# Altium schematic sheet SC20100S-Power.SchDoc(SC20100S-Power)
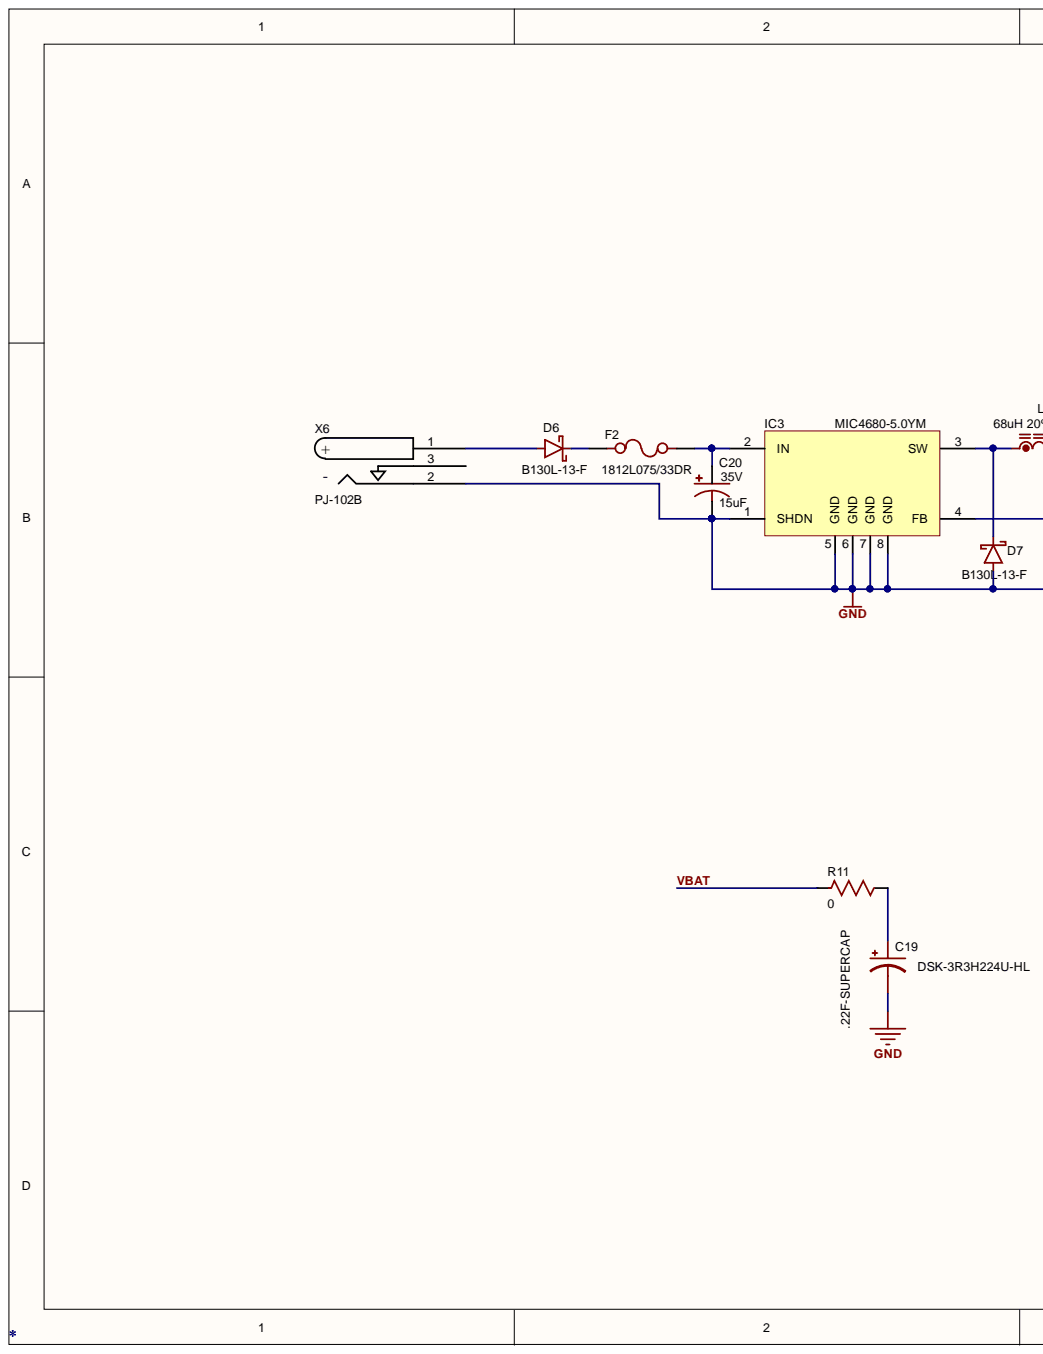
[diagram p0: SC20100S-Power.SchDoc, left]
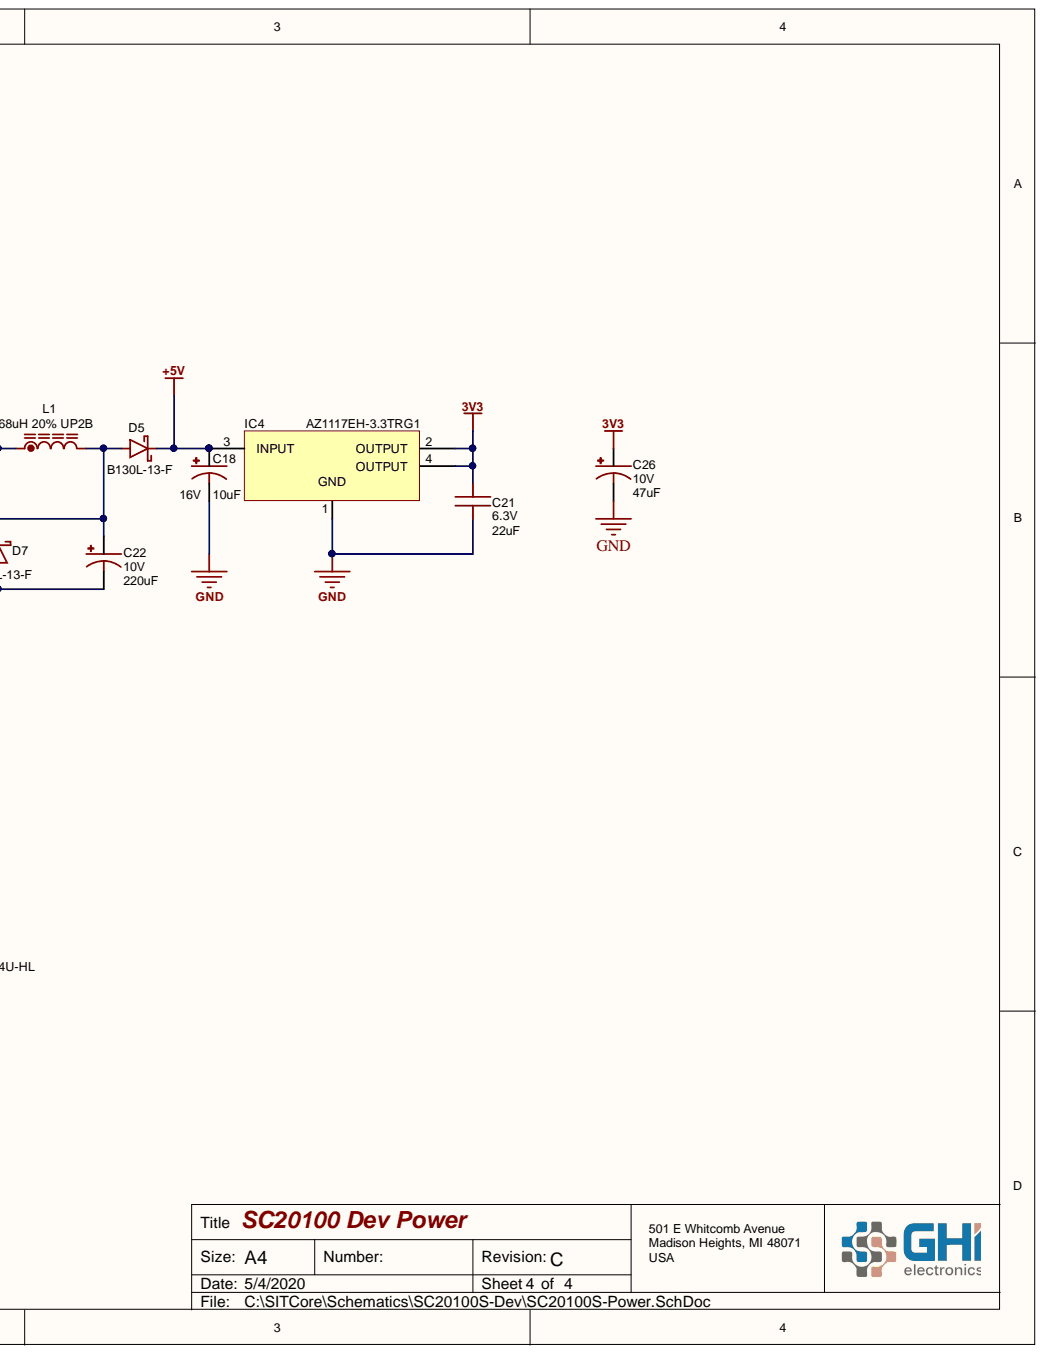
[diagram p1: SC20100S-Power.SchDoc, right]

## Parts
C18  value 10uF  Voltage: 16V  [CASE-B (3528 METRIC)]  (hidden comment: CASE-B  3528 Tant 10uF)
C19  .22F-SUPERCAP  value DSK-3R3H224U-HL  [DSK-RADIAL-6.8MM]
C20  value 15uF  Voltage: 35V  [CASE-D (7343 METRIC)]  (hidden comment: CASE-D 7343 Tant 15uF)
C21  value 22uF  Voltage: 6.3V  [C0805]  (hidden comment: 0805 Cap 22uF)
C22  value 220uF  Voltage: 10V  [CASE-D (7343 METRIC)]  (hidden comment: CASE-D 7343 Tant 220uF)
C26  value 47uF  Voltage: 10V  [CASE-B (3528 METRIC)]  (hidden comment: CASE-B  Tant 47uF)
D5  B130L-13-F  [SMA]
D6  B130L-13-F  [SMA]
D7  B130L-13-F  [SMA]
F2  value 1812L075/33DR  [1812 Fuse]
IC3  MIC4680-5.0YM  [8-Pin SOIC]
IC4  AZ1117EH-3.3TRG1  [SOT223]
L1  68uH 20% UP2B  Tolerance: 20%  Package: UP2B  Inductance: 68uH  [UP2BXXX]
R11  value 0  [R0603]  (hidden comment: 0603 Res 0)
X6  PJ-102B  [PJ-102B Power Connector]

## Nets
3V3: C21.2 C26.+ IC4.2(OUTPUT) IC4.4(OUTPUT)
+5V: C18.+ D5.K IC4.3(INPUT)
GND: C18.- C19.- C20.- C21.1 C22.- C26.- D7.A IC3.1(SHDN) IC3.5(GND) IC3.6(GND) IC3.7(GND) IC3.8(GND) IC4.1 X6.2
VBAT: R11.1
NetC19_+: C19.+ R11.2
NetC20_+: C20.+ F2.2 IC3.2(IN)
NetC22_+: C22.+ D5.A IC3.4(FB) L1.2
NetD6_A: D6.A X6.1
NetD6_K: D6.K F2.1
NetD7_K: D7.K IC3.3(SW) L1.1

Not connected: X6 3

## Library data of the parts
C18 = CASE-B Tant 10uF: 10uF ±10% 16V 800 mΩ @ 100kHz -55℃ ~ +125℃ CASE-B_3528 Tantalum Capacitors RoHS; Mfr.Part #: TPSB106K016R0800; Tolerance: ±10%; Package: CASE-B_3528; LCSC Part #: C273667; Digikey: 478-2398-1-ND; Component Kind: Standard; Category: Tantalum; Capacitance: 10uF; Library Name: GHI-Passives.SchLib
C19 = .22F-SUPERCAP: ELNA DSK-621 - 220mF Electric Double Layer Capacitor (EDLC/SuperCapacitor)    A 220 mF SuperCapacitor. Used for backup power on devices with low standby current; Manufacturer: Elna America; Mfr.Part #: DSK-3R3H224U-HL; Digikey: 604-1020-1-ND; Component Kind: Standard; Library Name: GHI.SchLib
C20 = CASE-D 7343 Tant 15uF: 15uF ±10% 35V 700 mΩ @ 100kHz -55℃ ~ +125℃ CASE-D_7343 Tantalum Capacitors RoHS; Mfr.Part #: 293D156X9035D2TE3; Tolerance: ±10%; Package: CASE-D_7343; LCSC Part #: C162325; Component Kind: Standard; Category: Tantalum; Capacitance: 15uF; Library Name: GHI-Passives.SchLib
C21 = 0805 Cap 22uF: 22uF ±20% 6.3V X5R 0805 Multilayer Ceramic Capacitors MLCC - SMD/SMT RoHS; Mfr.Part #: CL21A226MQCLRNC; Tolerance: ±20%; Package: 0805; LCSC Part #: C307530; Component Kind: Standard; Category: Ceramic; Capacitance: 22uF; Library Name: GHI-Passives.SchLib
C22 = CASE-D 7343 Tant 220uF: 220uF ±10% 10V 500 mΩ @ 100kHz -55℃ ~ +125℃ CASE-D_7343 Tantalum Capacitors RoHS; Mfr.Part #: T491D227K010AT; Manufacturer: KEMET; Tolerance: ±10%; Package: CASE-D_7343; LCSC Part #: C116577; Component Kind: Standard; Category: Tantalum; Capacitance: 220uF; Library Name: GHI-Passives.SchLib
C26 = CASE-B  Tant 47uF: 47uF ±10% 10V 1 Ω @ 100kHz -55℃ ~ +125℃ CASE-B_3528 Tantalum Capacitors RoHS; Mfr.Part #: TAJB476K010RNJ; Tolerance: ±10%; Package: CASE-B 3528; LCSC Part #: C22036; Component Kind: Standard; Category: Tantalum; Capacitance: 47uF; Library Name: GHI-Passives.SchLib
D5-D7 = B130L-13-F 1A Schottky SMA: 30V 1A 410mV @ 1A SMA(DO-214AC) Schottky Barrier Diodes (SBD) RoHS; Manufacturer: Diodes Incorporated; GHI Part Number: EPC-DB130L-13; Value: B130L-13-F; Package: SMA(DO-214AC); Mfr.Part #: B130L-13-F; Library Name: GHI.SchLib; Component Type: Diode; Component Kind: Standard
F2 = FUSE 33V 750MA 1812: Polymeric PTC Resettable Fuse 33V 750mA Ih Surface Mount 1812 (4532 Metric), Concave; Manufacturer: Littelfuse Inc.; Voltage - Max: PTC RESET FUSE 33V 750MA 1812; Value: 1812L075/33DR; Current - Trip (It): 1.5A; Package: 1812; Mfr.Part #: 1812L075/33DR; LCSC Part #: C151170; Component Kind: Standard; : FUSE 33V 750MA 1812; Library Name: GHI-Passives.SchLib
IC3 = MIC4680-5.0YM: Micrel 1A 200kHz SuperSwitcher Buck Regulator; Value: MIC4680-5.0YM; PartNo: MIC4680-5.0YM; Component Kind: Standard; Library Name: GHI IC.SchLib
IC4 = AZ1117EH-3.3TRG1: Diodes 1.0A low dropout linear regulator; Value: AZ1117EH-3.3TRG1; PartNo: AZ1117EH-3.3TRG1; Component Kind: Standard; Library Name: GHI.SchLib
L1 = 68uH 20%: Inductor 6.8uH 20% UP2B; GHI Part Number: EPC-LUP25-680; Value: UP2B-680-R; PartNo: UP2B-680-R; Library Name: GHI Basic Components.SchLib; Component Type: Inductor; Component Kind: Standard
R11 = 0603 Res 0: ±5% 1/10W 0603 Chip Resistor - Surface Mount RoHS; Mfr.Part #: RC0603JR-070RL; Manufacturer: YAGEO; Voltage Rating: *V; Resistance Tolerance: ±5%; Resistance: 0; Power Rating: 1/10W; Package: 0603; LCSC Part #: C95177; Component Kind: Standard; Library Name: GHI-Passives.SchLib
X6 = PJ-102B: Component Kind: Standard; Library Name: GHI Connectors.SchLib
